# Altium schematic sheet DigitalLabBoard_Traffic.SchDoc(DigitalLabBoard_Traffic)
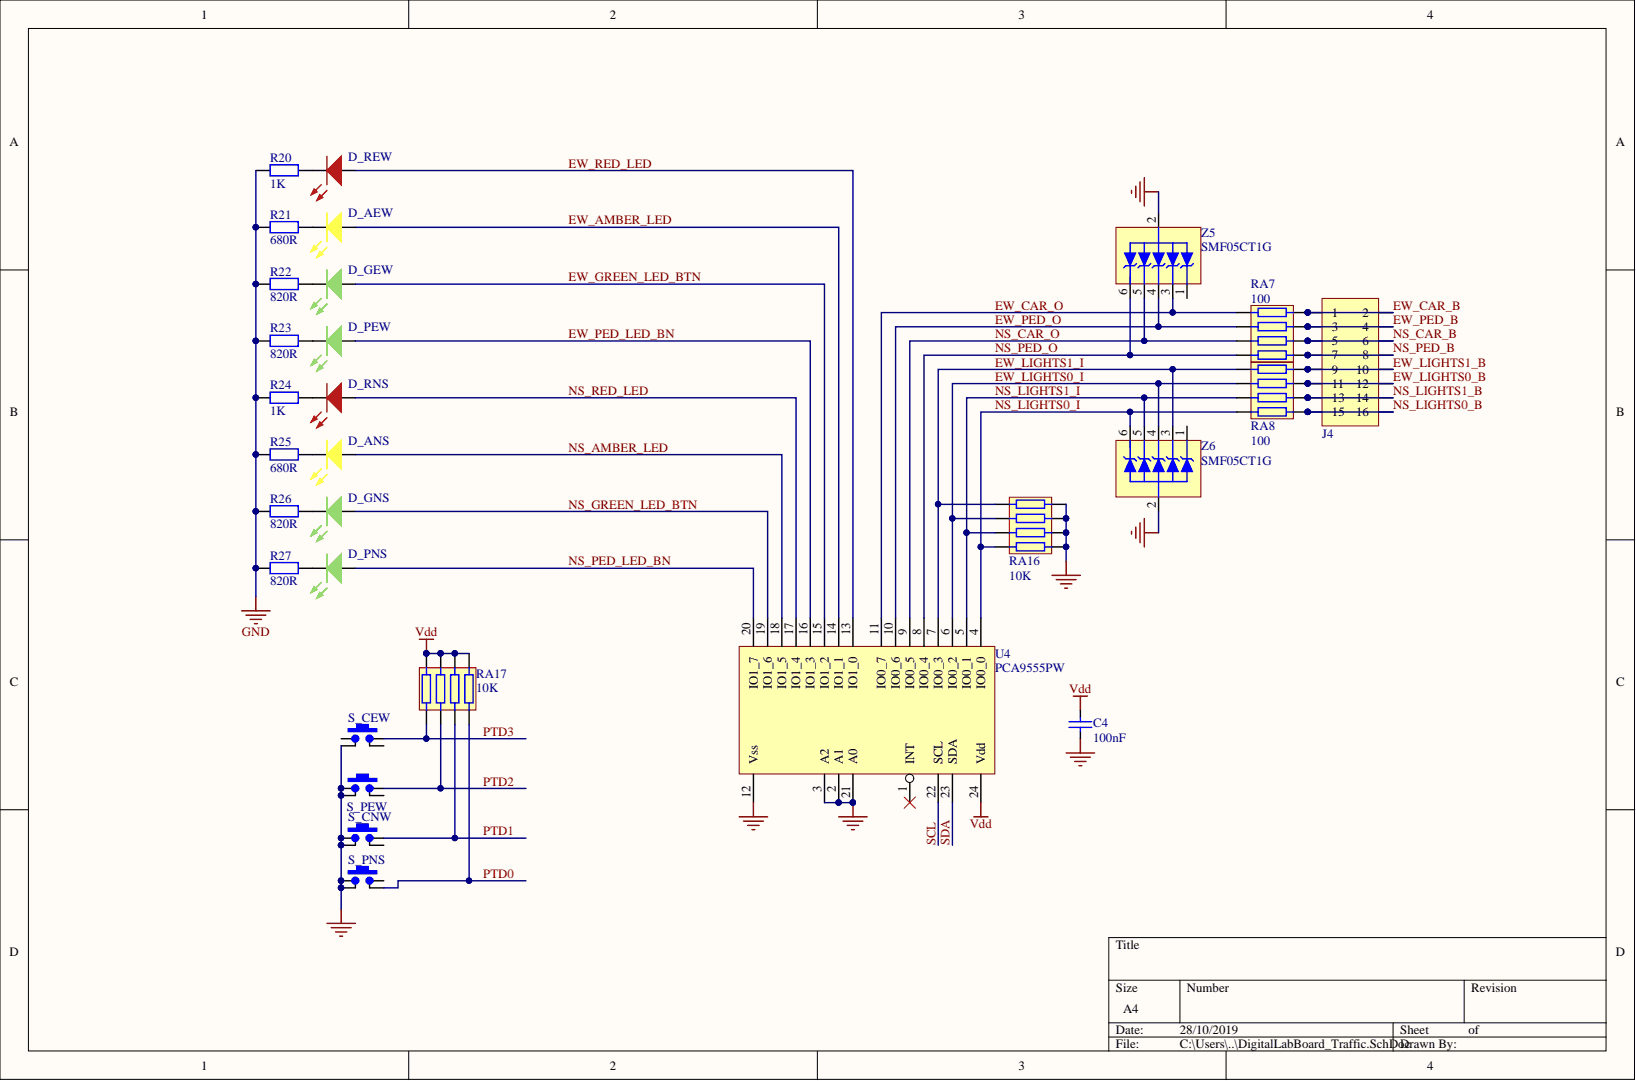
[diagram p0: DigitalLabBoard_Traffic.SchDoc]

## Parts
C4  100nF  [CCNP2012-0805]
D_AEW  LED Yellow  [LED1608-0603-Yellow]  (value not drawn)
D_ANS  LED Yellow  [LED1608-0603-Yellow]  (value not drawn)
D_GEW  LED Green  [LED1608-0603-GREEN]  (value not drawn)
D_GNS  LED Green  [LED1608-0603-GREEN]  (value not drawn)
D_PEW  LED Green  [LED1608-0603-GREEN]  (value not drawn)
D_PNS  LED Green  [LED1608-0603-GREEN]  (value not drawn)
D_REW  LED Red  [LED1608-0603-RED]  (value not drawn)
D_RNS  LED Red  [LED1608-0603-RED]  (value not drawn)
J4  2x5 Header  [HDR2X8S]  (value not drawn)
R20  1K  [CR1608-0603]
R21  680R  [CR1608-0603]
R22  820R  [CR1608-0603]
R23  820R  [CR1608-0603]
R24  1K  [CR1608-0603]
R25  680R  [CR1608-0603]
R26  820R  [CR1608-0603]
R27  820R  [CR1608-0603]
RA7  100  [CR1206-0603_X4]
RA8  100  [CR1206-0603_X4]
RA16  10K  [CR1206-0603_X4]
RA17  10K  [CR1206-0603_X4]
S_CEW  SW_PB  [SW-SMD-4_5.1x5.1x2]  (value not drawn)
S_CNW  SW_PB  [SW-SMD-4_5.1x5.1x2]  (value not drawn)
S_PEW  SW_PB  [SW-SMD-4_5.1x5.1x2]  (value not drawn)
S_PNS  SW_PB  [SW-SMD-4_5.1x5.1x2]  (value not drawn)
U4  PCA9555PW  [SOP65P780-24L]
Z5  SMF05CT1G  [SC70_N]
Z6  SMF05CT1G  [SC70_N]

## Nets
GND: C4.1 R20.1 R21.1 R22.1 R23.1 R24.1 R25.1 R26.1 R27.1 RA16.1 RA16.2 RA16.3 RA16.4 S_CEW.1 S_CNW.1 S_CNW.1b S_PEW.2 S_PEW.2b S_PNS.1 S_PNS.1b U4.2(A1) U4.3(A2) U4.12(Vss) U4.21(A0) Z5.2 Z6.2
Vdd: C4.2 RA17.1 RA17.2 RA17.3 RA17.4 U4.24(Vdd)
EW_AMBER_LED: D_AEW.A U4.14(IO1_1)
EW_CAR_B: J4.1 J4.2 RA7.1
EW_CAR_O: RA7.8 U4.11(IO0_7) Z5.3
EW_GREEN_LED_BTN: D_GEW.A U4.15(IO1_2)
EW_LIGHTS0_B: J4.11 J4.12 RA8.2
EW_LIGHTS0_I: RA8.7 RA16.7 U4.6(IO0_2) Z6.4
EW_LIGHTS1_B: J4.9 J4.10 RA8.1
EW_LIGHTS1_I: RA8.8 RA16.8 U4.7(IO0_3) Z6.3
EW_PED_B: J4.3 J4.4 RA7.2
EW_PED_LED_BN: D_PEW.A U4.16(IO1_3)
EW_PED_O: RA7.7 U4.10(IO0_6) Z5.4
EW_RED_LED: D_REW.A U4.13(IO1_0)
NS_AMBER_LED: D_ANS.A U4.18(IO1_5)
NS_CAR_B: J4.5 J4.6 RA7.3
NS_CAR_O: RA7.6 U4.9(IO0_5) Z5.5
NS_GREEN_LED_BTN: D_GNS.A U4.19(IO1_6)
NS_LIGHTS0_B: J4.15 J4.16 RA8.4
NS_LIGHTS0_I: RA8.5 RA16.5 U4.4(IO0_0) Z6.6
NS_LIGHTS1_B: J4.13 J4.14 RA8.3
NS_LIGHTS1_I: RA8.6 RA16.6 U4.5(IO0_1) Z6.5
NS_PED_B: J4.7 J4.8 RA7.4
NS_PED_LED_BN: D_PNS.A U4.20(IO1_7)
NS_PED_O: RA7.5 U4.8(IO0_4) Z5.6
NS_RED_LED: D_RNS.A U4.17(IO1_4)
PTD0: RA17.5 S_PNS.2
PTD1: RA17.6 S_CNW.2b
PTD2: RA17.7 S_PEW.1b
PTD3: RA17.8 S_CEW.2b
SCL: U4.22(SCL)
SDA: U4.23(SDA)
NetD_AEW_K: D_AEW.K R21.2
NetD_ANS_K: D_ANS.K R25.2
NetD_GEW_K: D_GEW.K R22.2
NetD_GNS_K: D_GNS.K R26.2
NetD_PEW_K: D_PEW.K R23.2
NetD_PNS_K: D_PNS.K R27.2
NetD_REW_K: D_REW.K R20.2
NetD_RNS_K: D_RNS.K R24.2

Not connected: S_CEW 1b 2; S_CNW 2; S_PEW 1; S_PNS 2b; U4 1(INT); Z5 1; Z6 1

## Library data of the parts
C4 = Cap_CCNP_0805: Capacitor non-polar SMT 0805; Manufacturer: Generic Components; Class: Passive; Value: 100nF; SubClass: Capacitor; Simulation: CAP; Signal Integrity: Cap; Published: 08/06/2000; Library Name: MyLibrary.IntLib; Component Kind: Standard; Publisher: Altium Hobart Technology Centre
D_AEW D_ANS = LED-0603-Yellow: LED 0603 Yellow; Manufacturer: Generic Components; Class I: Optoelectronic; Revision: July-2002: Re-released for DXP Platform.; Published: 8-Jun-2000; Library Name: MySchLib.schlib; Farnell PN: 5790852; Component Kind: Standard; Class II: LED; Publisher: Altium Limited
D_GEW D_GNS D_PEW D_PNS = LED-0603-Green: LED 0603 Green; Manufacturer: Generic Components; Class I: Optoelectronic; Revision: July-2002: Re-released for DXP Platform.; Published: 8-Jun-2000; Library Name: MySchLib.schlib; Farnell PN: 1581242; Component Kind: Standard; Class II: LED; Publisher: Altium Limited
D_REW D_RNS = LED-0603-Red: LED 0603 Red; Manufacturer: Generic Components; Class I: Optoelectronic; Revision: July-2002: Re-released for DXP Platform.; Published: 8-Jun-2000; Library Name: MySchLib.schlib; Farnell PN: 1581239; Component Kind: Standard; Class II: LED; Publisher: Altium Limited
J4 = Header 2x8a: Header 2x8; Library Name: MyLibrary.IntLib; Component Kind: Standard
R20-R27 = Res_CR0603: Resistor SMT 0603; Manufacturer: Generic Components; Class: Passive; SubClass: Resistor; Simulation: RESISTOR; Signal Integrity: Res; Published: 08/06/2000; Library Name: MyLibrary.IntLib; Component Kind: Standard; Publisher: Altium Hobart Technology Centre
  R20 R24: Value: 1K
  R21 R25: Value: 680R
  R22 R23 R26 R27: Value: 820R
RA7 RA8 RA16 RA17 = Res_CR0603x4: SMT x4 Resistor Array; Library Name: MyLibrary.IntLib; Component Kind: Standard
  RA7 RA8: Value: 100
  RA16 RA17: Value: 10K
S_CEW S_CNW S_PEW S_PNS = SW_PB-ALPS-SKQGAFE010: Tactile Switch, Top Actuated, Surface Mount, Round Button; Manufacturer: Multicomp; Revision: 1.0; Library Name: MySchLib.schlib; Farnell PN: 9471880; Component Kind: Standard
U4 = PCA9555PW: 16-bit I2C I/O Expander (TSSOP24); Library Name: MySchLib.schlib; Component Kind: Standard
Z5 Z6 = SMF05CT1G: TVS DIODE ARRAY, 100W, 5V, SC-70; Library Name: MySchLib.schlib; Farnell PN: 2533147; Component Kind: Standard
